# Altium schematic sheet MCU.SchDoc(MCU)
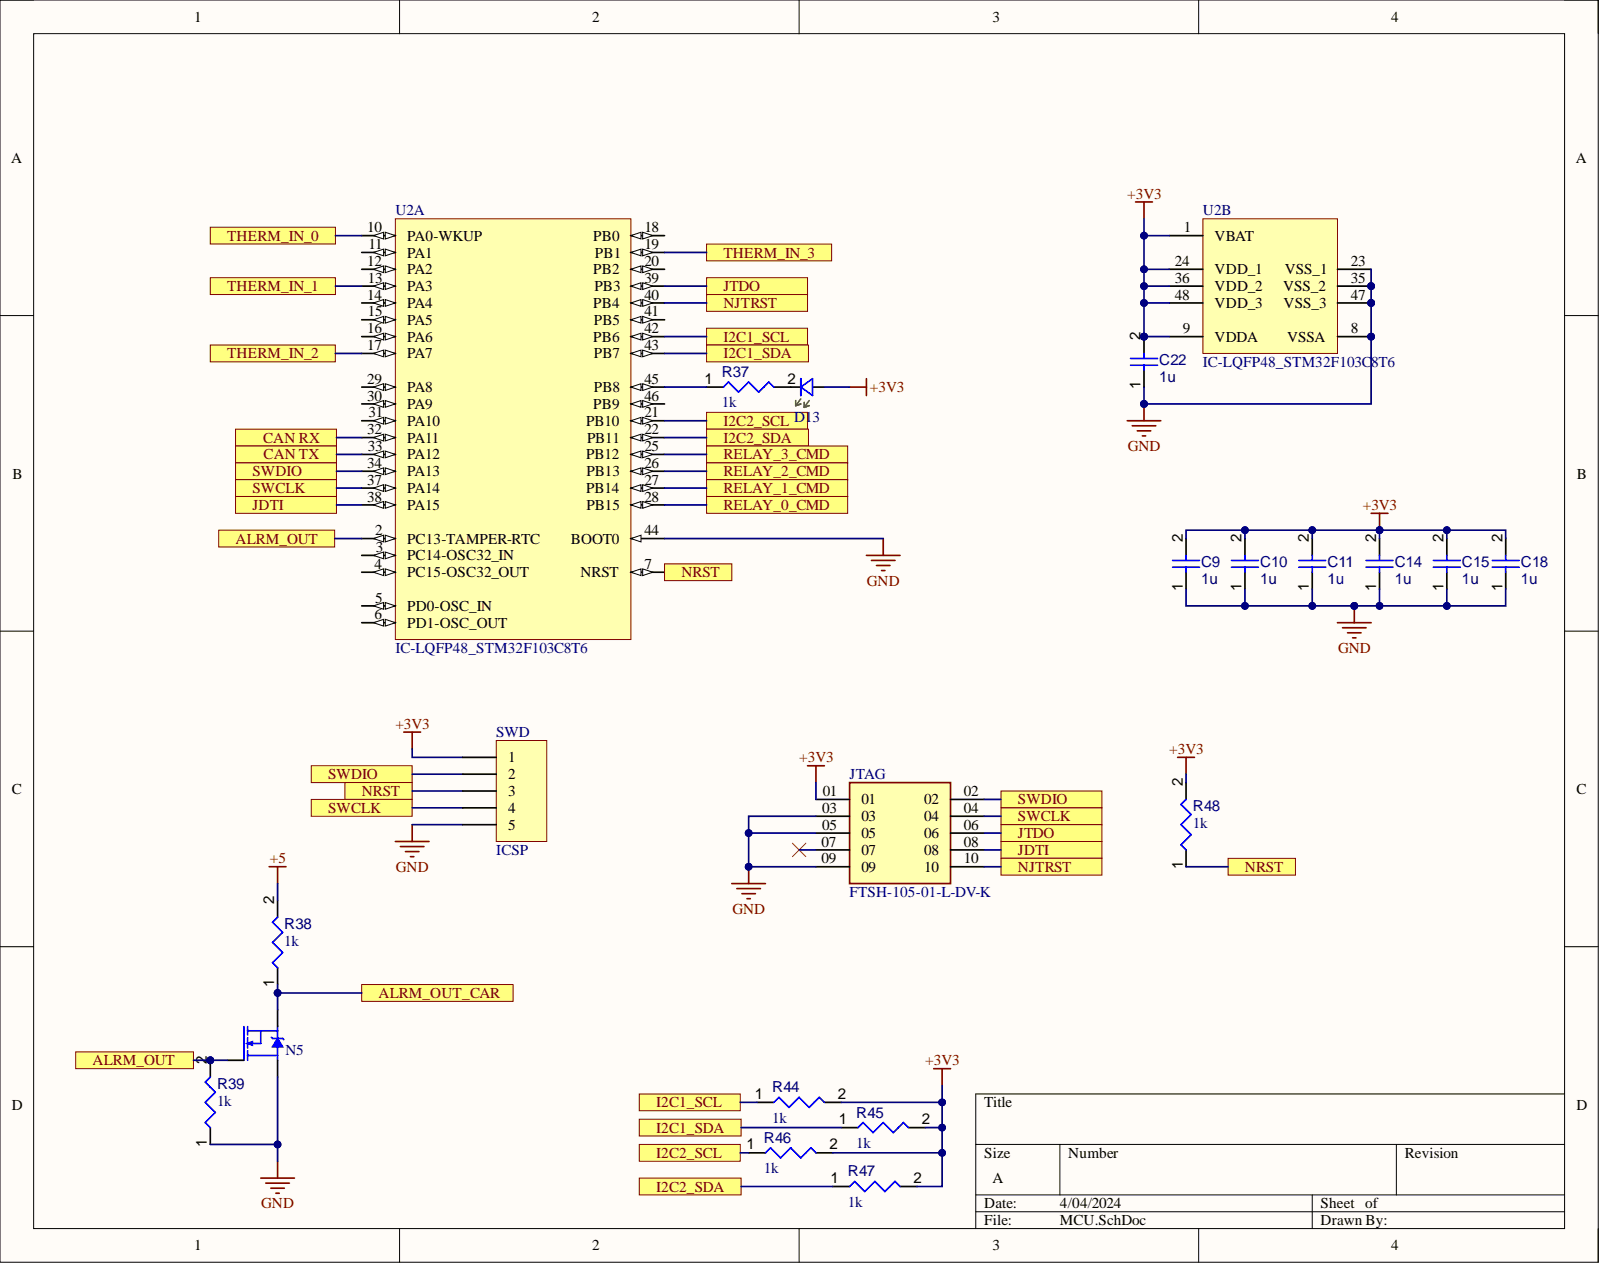
[diagram p0: MCU.SchDoc]

## Parts
C9  1u
C10  1u
C11  1u
C14  1u
C15  1u
C18  1u
C22  1u
D13  CMP-009-000195-1  (value not drawn)
JTAG  FTSH-105-01-L-DV-K
N5  NMOS-SOT23  (value not drawn)
R37  value 1k
R38  value 1k
R39  value 1k
R44  value 1k
R45  value 1k
R46  value 1k
R47  value 1k
R48  value 1k
SWD  ICSP
U2  IC-LQFP48_STM32F103C8T6  (parts A,B)

## Ports
ALRM_OUT, ALRM_OUT_CAR, CAN RX, CAN TX, I2C1_SCL, I2C1_SDA, I2C2_SCL, I2C2_SDA, JDTI, JTDO, NJTRST, NRST, RELAY_0_CMD, RELAY_1_CMD, RELAY_2_CMD, RELAY_3_CMD, SWCLK, SWDIO, THERM_IN_0, THERM_IN_1, THERM_IN_2, THERM_IN_3

## Nets
+3V3: C9.2 C10.2 C11.2 C14.2 C15.2 C18.2 C22.2 D13.A JTAG.01 R44.2 R45.2 R46.2 R47.2 R48.2 SWD.1 U2.1(VBAT) U2.9(VDDA) U2.24(VDD_1) U2.36(VDD_2) U2.48(VDD_3)
+5: R38.2
GND: C9.1 C10.1 C11.1 C14.1 C15.1 C18.1 C22.1 JTAG.03 JTAG.05 JTAG.09 N5.2 R39.1 SWD.5 U2.8(VSSA) U2.23(VSS_1) U2.35(VSS_2) U2.44(BOOT0) U2.47(VSS_3)
ALRM_OUT: N5.1 R39.2 U2.2(PC13-TAMPER-RTC) ; port ALRM_OUT
ALRM_OUT_CAR: N5.3 R38.1 ; port ALRM_OUT_CAR
CAN RX: U2.32(PA11) ; port CAN RX
CAN TX: U2.33(PA12) ; port CAN TX
I2C1_SCL: R44.1 U2.42(PB6) ; port I2C1_SCL
I2C1_SDA: R45.1 U2.43(PB7) ; port I2C1_SDA
I2C2_SCL: R46.1 U2.21(PB10) ; port I2C2_SCL
I2C2_SDA: R47.1 U2.22(PB11) ; port I2C2_SDA
JDTI: JTAG.08 U2.38(PA15) ; port JDTI
JTDO: JTAG.06 U2.39(PB3) ; port JTDO
NJTRST: JTAG.10 U2.40(PB4) ; port NJTRST
NRST: R48.1 SWD.3 U2.7(NRST) ; port NRST
RELAY_0_CMD: U2.28(PB15) ; port RELAY_0_CMD
RELAY_1_CMD: U2.27(PB14) ; port RELAY_1_CMD
RELAY_2_CMD: U2.26(PB13) ; port RELAY_2_CMD
RELAY_3_CMD: U2.25(PB12) ; port RELAY_3_CMD
SWCLK: JTAG.04 SWD.4 U2.37(PA14) ; port SWCLK
SWDIO: JTAG.02 SWD.2 U2.34(PA13) ; port SWDIO
THERM_IN_0: U2.10(PA0-WKUP) ; port THERM_IN_0
THERM_IN_1: U2.13(PA3) ; port THERM_IN_1
THERM_IN_2: U2.17(PA7) ; port THERM_IN_2
THERM_IN_3: U2.19(PB1) ; port THERM_IN_3
NetD13_K: D13.K R37.2
NetR37_1: R37.1 U2.45(PB8)

Not connected: JTAG 07; U2 3(PC14-OSC32_IN) 4(PC15-OSC32_OUT) 5(PD0-OSC_IN) 6(PD1-OSC_OUT) 11(PA1) 12(PA2) 14(PA4) 15(PA5) 16(PA6) 18(PB0) 20(PB2) 29(PA8) 30(PA9) 31(PA10) 41(PB5) 46(PB9)

## Library data of the parts
C9-C11 C14 C15 C18 C22 = CMP-005-000616-1: Chip Capacitor, 2,2µF +/-20%, 10V, 0402, Thickness 0.6 mm; Available Preview Images: 2D; Component Kind: Standard; Design Item ID: CMP-001-00056-2; Source: Integrated_Library - 12.03.21 - 15.39.SchLib; Library Name: Illini Formula Electric
JTAG = CMP-057-000110-1: Connector Header Surface Mount 10 position 0.050" (1.27mm)  <a href="https://pricing.snapeda.com/parts/FTSH-105-01-L-DV-K/Samtec/view-part?ref=eda">Check availability</a>; MF: Samtec; Availability: Unavailable; Component Kind: Standard; Component Type: Standard; Design Item ID: FTSH-105-01-L-DV-K; MP: FTSH-105-01-L-DV-K; Package: None; Pin Count: 10; Price: None; Source: FTSH-105-01-L-DV-K.SchLib; Library Name: Illini Formula Electric
N5 = CMP-009-000184-1: Component Kind: Standard; Design Item ID: NMOS-SOT23; Library Name: Illini Formula Electric; Source: ife19_Elec_Library.SchLib
R37-R39 R44-R48 = CMP-009-000068-1: 120R 0.1W 5% 0603 (1608 Metric)  SMD; Available Preview Images: 2D; Case-EIA: 0603; Case-Metric: 1608; Component Kind: Standard; Design Item ID: CMP-009-00069-1; Source: Integrated_Library - 12.03.21 - 15.39.SchLib; Technology: SMT; Library Name: Illini Formula Electric
SWD = CMP-050-000095-1: Component Kind: Standard; Component Type: Standard; Design Item ID: ICSP; Pin Count: 5; Source: TC2030.SchLib; Library Name: Illini Formula Electric
U2 = CMP-009-000216-1: A/D Converter: 2x12-bit (10 Channel); Core: ARM Cortex-M3; FLASH Size (Prog) (kB): 64; I/Os (High Current): 37; Internal RAM Size (kB): 20; Operating Frequency(F) (Processor speed) (MHz): 72; Packing: Tray; Supply Voltage(Vcc) min (V): 2; Component Kind: Standard; ComponentLink1Description: Manufacturer URL; ComponentLink1URL: http://www.st.com/web/en/home.html; ComponentLink2Description: Datasheet; ComponentLink2URL: http://www.st.com/st-web-ui/static/active/en/resource/technical/document/datasheet/CD00161566.pdf; ComponentLink3Description: Package Specification; ComponentLink3URL: http://www.st.com/st-web-ui/static/active/en/resource/technical/document/datasheet/DM00058181.pdf; DatasheetVersion: Rev. 16; Design Item ID: IC-LQFP48_STM32F103C8T6; Mounting Technology: Surface Mount; PackageDescription: 48-Pin Low Profile Quad Flat Package, 7 x 7 mm Body, 0.5 mm Pitch; PackageReference: LQFP48; PackageVersion: Rev. 6, 06/2013; PartNumber: STM32F103C8T6; Library Name: Illini Formula Electric; Source: ife19_Elec_Library.SchLib
D13 = CMP-009-000195-1: Component Kind: Standard; Design Item ID: LEDGREEN-0603; Library Name: Illini Formula Electric; Source: ife19_Elec_Library.SchLib
